# Altium schematic sheet Codec's.SchDoc
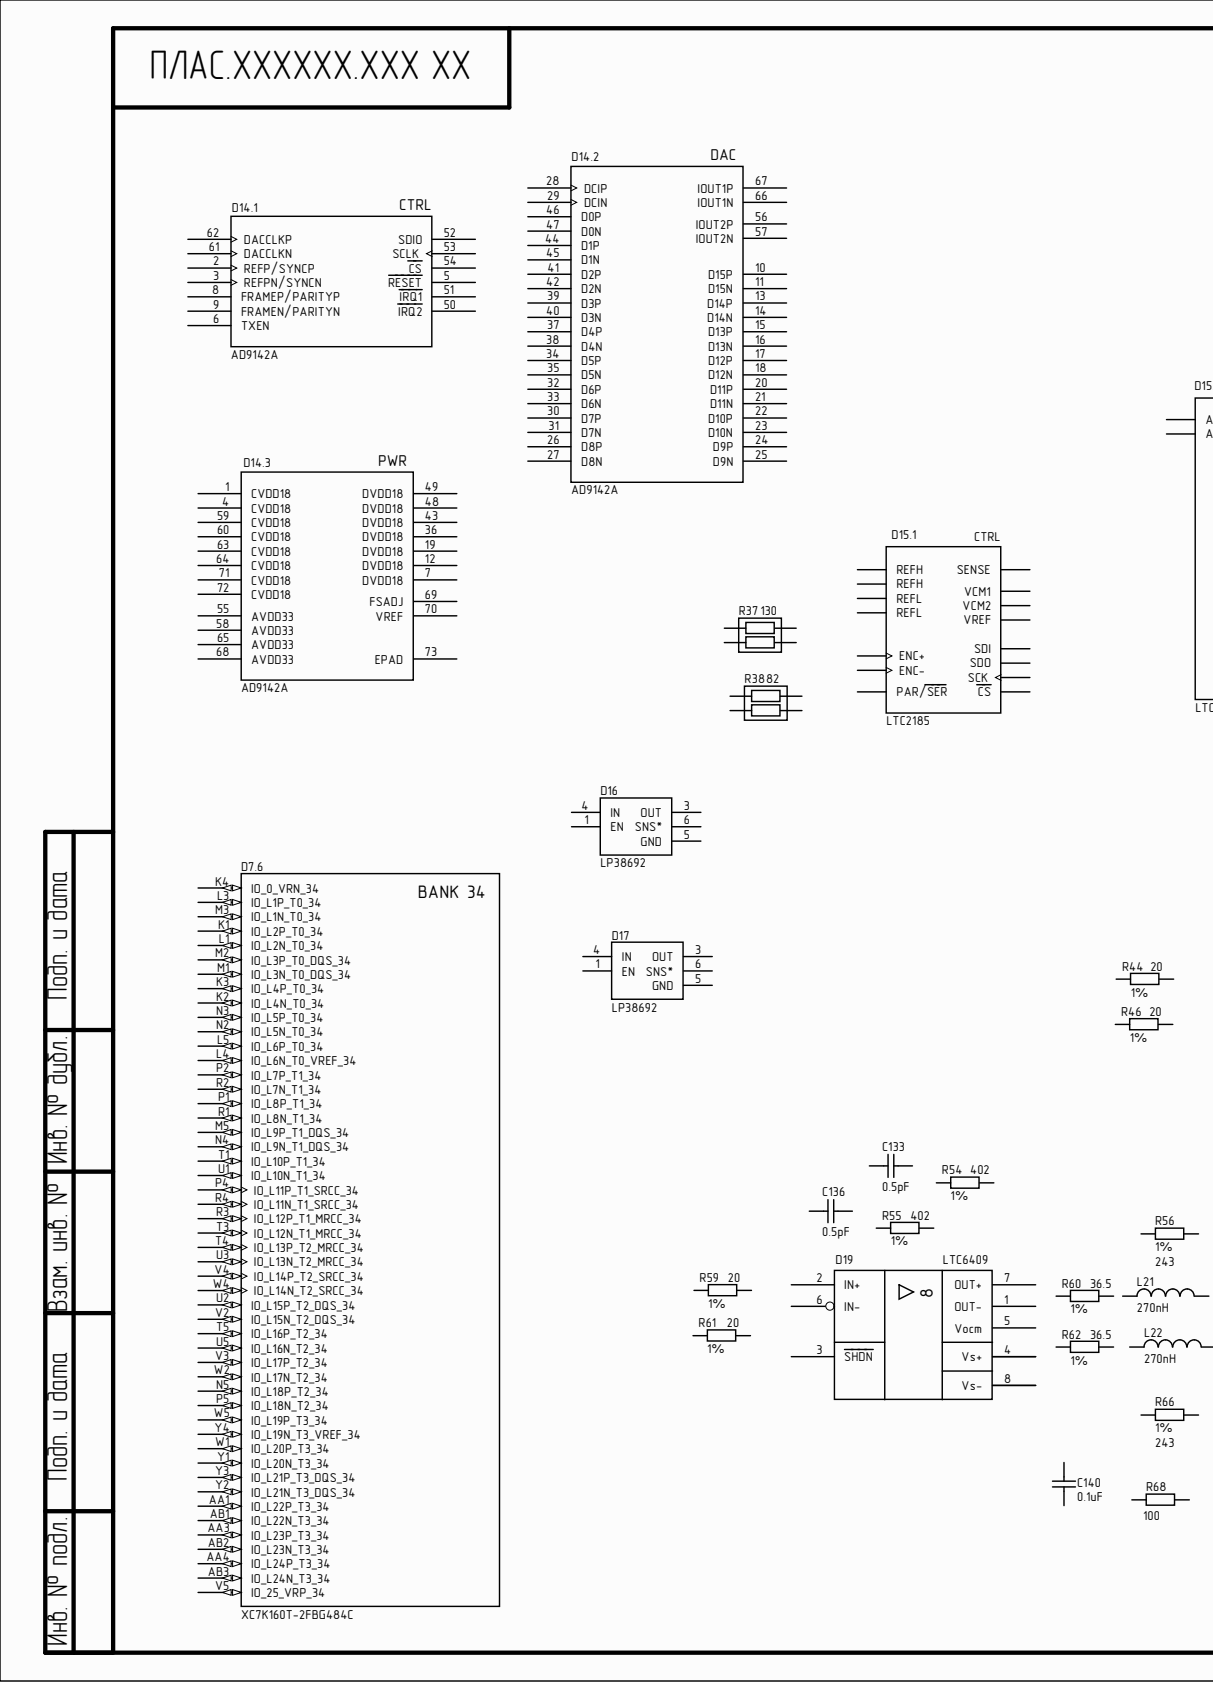
[diagram p0: Codec's.SchDoc, left]
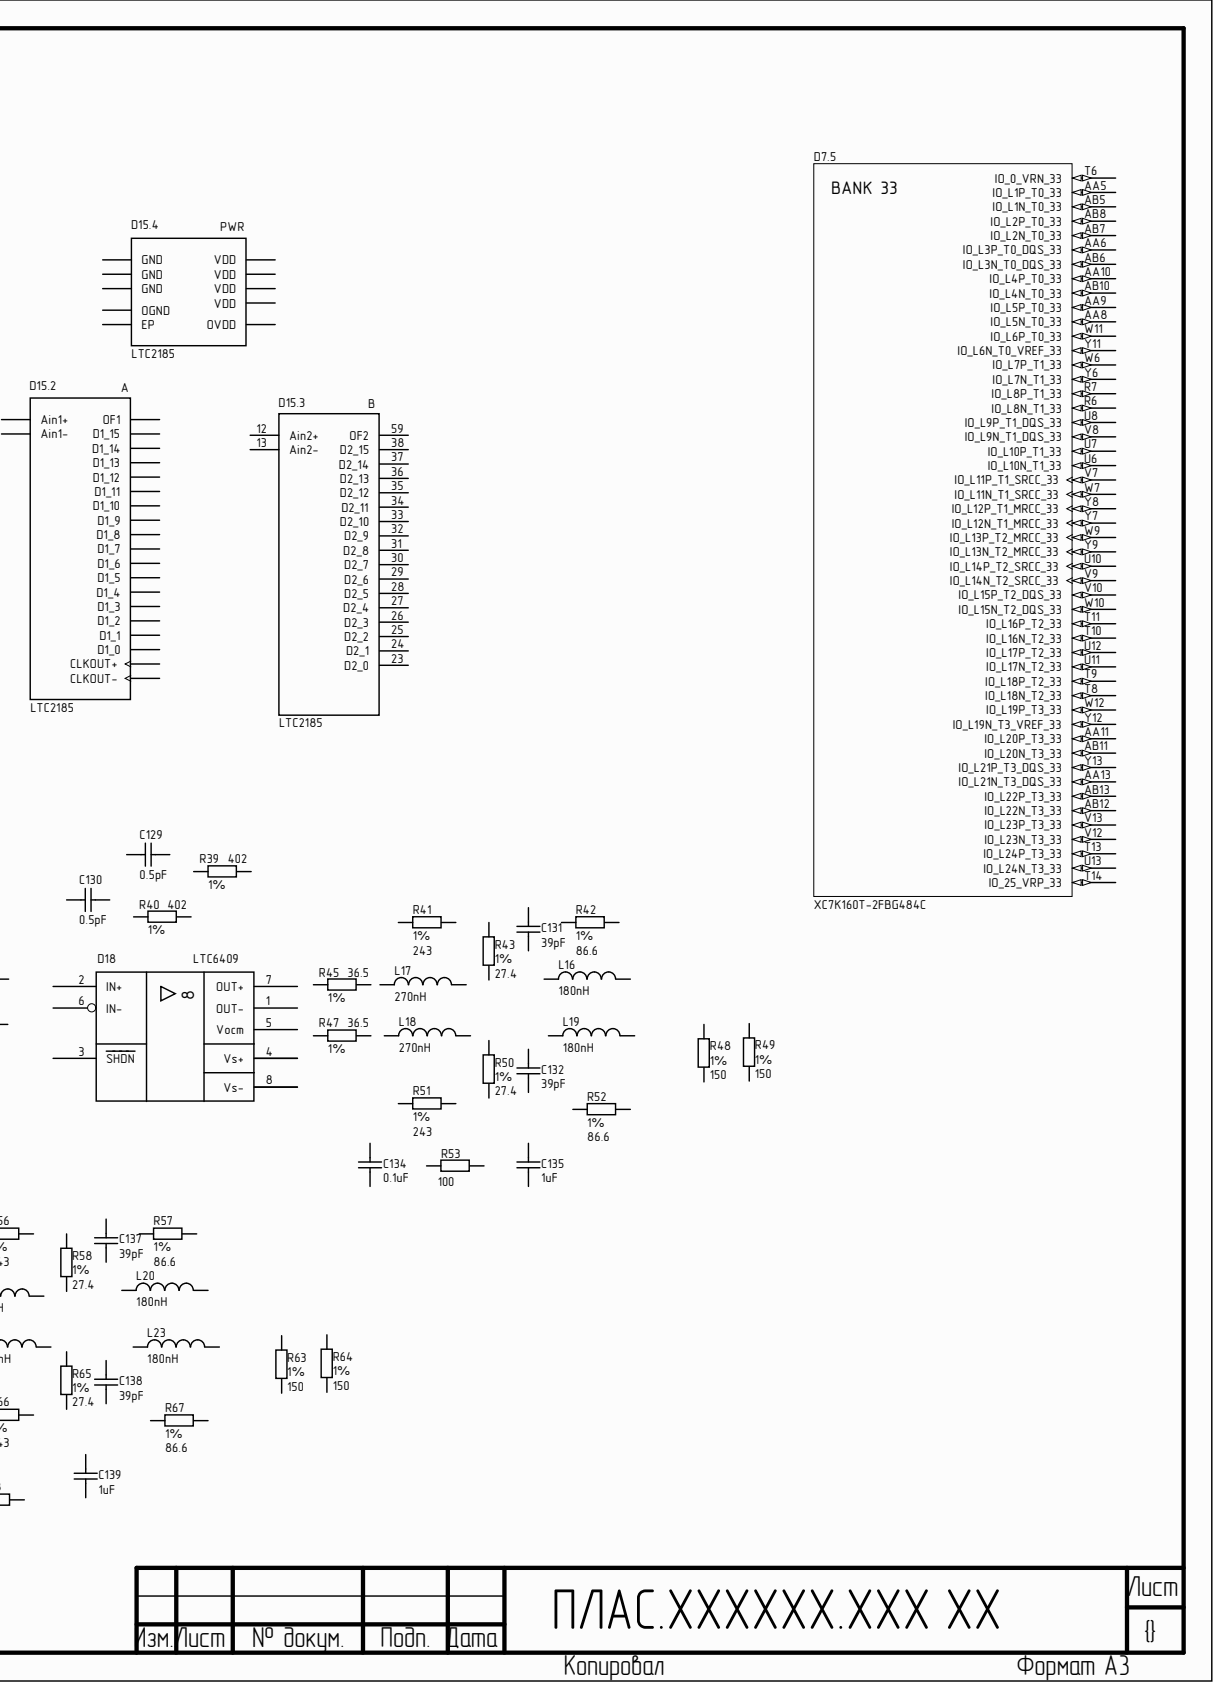
[diagram p1: Codec's.SchDoc, right]

## Parts
C129  value 0.5pF  Tolerance: 0.5pF  [C0402]  (hidden comment: CAP)
C130  value 0.5pF  Tolerance: 0.5pF  [C0402]  (hidden comment: CAP)
C131  value 39pF  [C0402]  (hidden comment: CAP)
C132  value 39pF  Tolerance: 1%  [C0402]  (hidden comment: CAP)
C133  value 0.5pF  Tolerance: 0.5pF  [C0402]  (hidden comment: CAP)
C134  value 0.1uF  [C0402]  (hidden comment: CAP)
C135  value 1uF  [C0402]  (hidden comment: CAP)
C136  value 0.5pF  Tolerance: 0.5pF  [C0402]  (hidden comment: CAP)
C137  value 39pF  Tolerance: 1%  [C0402]  (hidden comment: CAP)
C138  value 39pF  Tolerance: 1%  [C0402]  (hidden comment: CAP)
C139  value 1uF  [C0402]  (hidden comment: CAP)
C140  value 0.1uF  [C0402]  (hidden comment: CAP)
D7  XC7K160T-2FBG484C  [FBG484]  (parts .5,.6)
D14  AD9142A  [LFCSP_VQ-72]  (parts .1,.2,.3)
D15  LTC2185  [QFN-64]  (parts .1,.2,.3,.4)
D16  LP38692  [SOT-223-5]
D17  LP38692  [SOT-223-5]
D18  LTC6409  [QFN-10]
D19  LTC6409  [QFN-10]
L16  value 180nH  [L0603]  (hidden comment: Inductor RF)
L17  value 270nH  [L0603]  (hidden comment: Inductor RF)
L18  value 270nH  [L0603]  (hidden comment: Inductor RF)
L19  value 180nH  [L0603]  (hidden comment: Inductor RF)
L20  value 180nH  [L0603]  (hidden comment: Inductor RF)
L21  value 270nH  [L0603]  (hidden comment: Inductor RF)
L22  value 270nH  [L0603]  (hidden comment: Inductor RF)
L23  value 180nH  [L0603]  (hidden comment: Inductor RF)
R37  value 130  [EX24V]  (hidden comment: RES 2x0402)
R38  value 82  [EX24V]  (hidden comment: RES 2x0402)
R39  value 402  Tolerance: 1%  [R0402]  (hidden comment: RES)
R40  value 402  Tolerance: 1%  [R0402]  (hidden comment: RES)
R41  value 243  Tolerance: 1%  [R0402]  (hidden comment: RES)
R42  value 86.6  Tolerance: 1%  [R0402]  (hidden comment: RES)
R43  value 27.4  Tolerance: 1%  [R0402]  (hidden comment: RES)
R44  value 20  Tolerance: 1%  [R0402]  (hidden comment: RES)
R45  value 36.5  Tolerance: 1%  [R0402]  (hidden comment: RES)
R46  value 20  Tolerance: 1%  [R0402]  (hidden comment: RES)
R47  value 36.5  Tolerance: 1%  [R0402]  (hidden comment: RES)
R48  value 150  Tolerance: 1%  [R0402]  (hidden comment: RES)
R49  value 150  Tolerance: 1%  [R0402]  (hidden comment: RES)
R50  value 27.4  Tolerance: 1%  [R0402]  (hidden comment: RES)
R51  value 243  Tolerance: 1%  [R0402]  (hidden comment: RES)
R52  value 86.6  Tolerance: 1%  [R0402]  (hidden comment: RES)
R53  value 100  [R0402]  (hidden comment: RES)
R54  value 402  Tolerance: 1%  [R0402]  (hidden comment: RES)
R55  value 402  Tolerance: 1%  [R0402]  (hidden comment: RES)
R56  value 243  Tolerance: 1%  [R0402]  (hidden comment: RES)
R57  value 86.6  Tolerance: 1%  [R0402]  (hidden comment: RES)
R58  value 27.4  Tolerance: 1%  [R0402]  (hidden comment: RES)
R59  value 20  Tolerance: 1%  [R0402]  (hidden comment: RES)
R60  value 36.5  Tolerance: 1%  [R0402]  (hidden comment: RES)
R61  value 20  Tolerance: 1%  [R0402]  (hidden comment: RES)
R62  value 36.5  Tolerance: 1%  [R0402]  (hidden comment: RES)
R63  value 150  Tolerance: 1%  [R0402]  (hidden comment: RES)
R64  value 150  Tolerance: 1%  [R0402]  (hidden comment: RES)
R65  value 27.4  Tolerance: 1%  [R0402]  (hidden comment: RES)
R66  value 243  Tolerance: 1%  [R0402]  (hidden comment: RES)
R67  value 86.6  Tolerance: 1%  [R0402]  (hidden comment: RES)
R68  value 100  [R0402]  (hidden comment: RES)

## Nets
NetD18_4: D18.4(Vs+) D18.9
NetD18_8: D18.8(Vs-) D18.11
NetD19_4: D19.4(Vs+) D19.9
NetD19_8: D19.8(Vs-) D19.11

Not connected: C129 1 2; C130 1 2; C131 1 2; C132 1 2; C133 1 2; C134 1 2; C135 1 2; C136 1 2; C137 1 2; C138 1 2; C139 1 2; C140 1 2; D7 AA1(IO_L22P_T3_34) AA3(IO_L23P_T3_34) AA4(IO_L24P_T3_34) AA5(IO_L1P_T0_33) AA6(IO_L3P_T0_DQS_33) AA8(IO_L5N_T0_33) AA9(IO_L5P_T0_33) AA10(IO_L4P_T0_33) AA11(IO_L20P_T3_33) AA13(IO_L21N_T3_DQS_33) AB1(IO_L22N_T3_34) AB2(IO_L23N_T3_34) AB3(IO_L24N_T3_34) AB5(IO_L1N_T0_33) AB6(IO_L3N_T0_DQS_33) AB7(IO_L2N_T0_33) AB8(IO_L2P_T0_33) AB10(IO_L4N_T0_33) AB11(IO_L20N_T3_33) AB12(IO_L22N_T3_33) AB13(IO_L22P_T3_33) K1(IO_L2P_T0_34) K2(IO_L4N_T0_34) K3(IO_L4P_T0_34) K4(IO_0_VRN_34) L1(IO_L2N_T0_34) L3(IO_L1P_T0_34) L4(IO_L6N_T0_VREF_34) L5(IO_L6P_T0_34) M1(IO_L3N_T0_DQS_34) M2(IO_L3P_T0_DQS_34) M3(IO_L1N_T0_34) M5(IO_L9P_T1_DQS_34) N2(IO_L5N_T0_34) N3(IO_L5P_T0_34) N4(IO_L9N_T1_DQS_34) N5(IO_L18P_T2_34) P1(IO_L8P_T1_34) P2(IO_L7P_T1_34) P4(IO_L11P_T1_SRCC_34) P5(IO_L18N_T2_34) R1(IO_L8N_T1_34) R2(IO_L7N_T1_34) R3(IO_L12P_T1_MRCC_34) R4(IO_L11N_T1_SRCC_34) R6(IO_L8N_T1_33) R7(IO_L8P_T1_33) T1(IO_L10P_T1_34) T3(IO_L12N_T1_MRCC_34) T4(IO_L13P_T2_MRCC_34) T5(IO_L16P_T2_34) T6(IO_0_VRN_33) T8(IO_L18N_T2_33) T9(IO_L18P_T2_33) T10(IO_L16N_T2_33) T11(IO_L16P_T2_33) T13(IO_L24P_T3_33) T14(IO_25_VRP_33) U1(IO_L10N_T1_34) U2(IO_L15P_T2_DQS_34) U3(IO_L13N_T2_MRCC_34) U5(IO_L16N_T2_34) U6(IO_L10N_T1_33) U7(IO_L10P_T1_33) U8(IO_L9P_T1_DQS_33) U10(IO_L14P_T2_SRCC_33) U11(IO_L17N_T2_33) U12(IO_L17P_T2_33) U13(IO_L24N_T3_33) V2(IO_L15N_T2_DQS_34) V3(IO_L17P_T2_34) V4(IO_L14P_T2_SRCC_34) V5(IO_25_VRP_34) V7(IO_L11P_T1_SRCC_33) V8(IO_L9N_T1_DQS_33) V9(IO_L14N_T2_SRCC_33) V10(IO_L15P_T2_DQS_33) V12(IO_L23N_T3_33) V13(IO_L23P_T3_33) W1(IO_L20P_T3_34) W2(IO_L17N_T2_34) W4(IO_L14N_T2_SRCC_34) W5(IO_L19P_T3_34) W6(IO_L7P_T1_33) W7(IO_L11N_T1_SRCC_33) W9(IO_L13P_T2_MRCC_33) W10(IO_L15N_T2_DQS_33) W11(IO_L6P_T0_33) W12(IO_L19P_T3_33) Y1(IO_L20N_T3_34) Y2(IO_L21N_T3_DQS_34) Y3(IO_L21P_T3_DQS_34) Y4(IO_L19N_T3_VREF_34) Y6(IO_L7N_T1_33) Y7(IO_L12N_T1_MRCC_33) Y8(IO_L12P_T1_MRCC_33) Y9(IO_L13N_T2_MRCC_33) Y11(IO_L6N_T0_VREF_33) Y12(IO_L19N_T3_VREF_33) Y13(IO_L21P_T3_DQS_33); D14 1(CVDD18) 2(REFP/SYNCP) 3(REFPN/SYNCN) 4(CVDD18) 5(RESET) 6(TXEN) 7(DVDD18) 8(FRAMEP/PARITYP) 9(FRAMEN/PARITYN) 10(D15P) 11(D15N) 12(DVDD18) 13(D14P) 14(D14N) 15(D13P) 16(D13N) 17(D12P) 18(D12N) 19(DVDD18) 20(D11P) 21(D11N) 22(D10P) 23(D10N) 24(D9P) 25(D9N) 26(D8P) 27(D8N) 28(DCIP) 29(DCIN) 30(D7P) 31(D7N) 32(D6P) 33(D6N) 34(D5P) 35(D5N) 36(DVDD18) 37(D4P) 38(D4N) 39(D3P) 40(D3N) 41(D2P) 42(D2N) 43(DVDD18) 44(D1P) 45(D1N) 46(D0P) 47(D0N) 48(DVDD18) 49(DVDD18) 50(IRQ2) 51(IRQ1) 52(SDIO) 53(SCLK) 54(CS) 55(AVDD33) 56(IOUT2P) 57(IOUT2N) 58(AVDD33) 59(CVDD18) 60(CVDD18) 61(DACCLKN) 62(DACCLKP) 63(CVDD18) 64(CVDD18) 65(AVDD33) 66(IOUT1N) 67(IOUT1P) 68(AVDD33) 69(FSADJ) 70(VREF) 71(CVDD18) 72(CVDD18) 73(EPAD); D15 0(EP) 1(VDD) 2(VCM1) 3(GND) 4(Ain1+) 5(Ain1-) 6(GND) 7(REFH) 8(REFL) 9(REFH) 10(REFL) 11(PAR/SER) 12(Ain2+) 13(Ain2-) 14(GND) 15(VCM2) 16(VDD) 17(VDD) 18(ENC+) 19(ENC-) 20(CS) 21(SCK) 22(SDI) 23(D2_0) 24(D2_1) 25(D2_2) 26(D2_3) 27(D2_4) 28(D2_5) 29(D2_6) 30(D2_7) 31(D2_8) 32(D2_9) 33(D2_10) 34(D2_11) 35(D2_12) 36(D2_13) 37(D2_14) 38(D2_15) 39(CLKOUT-) 40(CLKOUT+) 41(OGND) 42(OVDD) 43(D1_0) 44(D1_1) 45(D1_2) 46(D1_3) 47(D1_4) 48(D1_5) 49(D1_6) 50(D1_7) 51(D1_8) 52(D1_9) 53(D1_10) 54(D1_11) 55(D1_12) 56(D1_13) 57(D1_14) 58(D1_15) 59(OF2) 60(OF1) 61(SDO) 62(VREF) 63(SENSE) 64(VDD); D16 1(EN) 3(OUT) 4(IN) 5(GND) 6(SNS*); D17 1(EN) 3(OUT) 4(IN) 5(GND) 6(SNS*); D18 1(OUT-) 2(IN+) 3(SHDN) 5(Vocm) 6(IN-) 7(OUT+); D19 1(OUT-) 2(IN+) 3(SHDN) 5(Vocm) 6(IN-) 7(OUT+); L16 1 2; L17 1 2; L18 1 2; L19 1 2; L20 1 2; L21 1 2; L22 1 2; L23 1 2; R37 1 2 3 4; R38 1 2 3 4; R39 1 2; R40 1 2; R41 1 2; R42 1 2; R43 1 2; R44 1 2; R45 1 2; R46 1 2; R47 1 2; R48 1 2; R49 1 2; R50 1 2; R51 1 2; R52 1 2; R53 1 2; R54 1 2; R55 1 2; R56 1 2; R57 1 2; R58 1 2; R59 1 2; R60 1 2; R61 1 2; R62 1 2; R63 1 2; R64 1 2; R65 1 2; R66 1 2; R67 1 2; R68 1 2

## Library data of the parts
C129 C130 C133 C136 = CAP: SMD Capacitor; Manufacturer: Murata; Material: NP0; P/N: GRM1555C1HR50BA01D; Type: Ceramic Capacitor; Voltage: 50V; Component Kind: Standard; Library Name: Kintex_SDR.SchLib
C131 = CAP: SMD Capacitor; Manufacturer: Murata; Material: NP0; P/N: GRM1555C1H390FA01D; Tolerance: 1%; Type: Ceramic Capacitor; Voltage: 50V; Component Kind: Standard; Library Name: Kintex_SDR.SchLib
C132 C137 C138 = CAP: SMD Capacitor; Manufacturer: Murata; Material: NP0; P/N: GRM1555C1H390FA01D; Type: Ceramic Capacitor; Voltage: 50V; Component Kind: Standard; Library Name: Kintex_SDR.SchLib
C134 C140 = CAP: SMD Capacitor; Manufacturer: Murata; Material: X7R; P/N: GRM155R71H104KE14J; Tolerance: 10%; Type: Ceramic Capacitor; Voltage: 50V; Component Kind: Standard; Library Name: Kintex_SDR.SchLib
C135 C139 = CAP: SMD Capacitor; Manufacturer: Murata; Material: X7R; P/N: GRM155R70J105KA12D; Tolerance: 10%; Type: Ceramic Capacitor; Voltage: 6.3V; Component Kind: Standard; Library Name: Kintex_SDR.SchLib
D7 = XC7K160T-2FBG484C: Xilinx 7-Series FPGA; Speed Grade: -2; Component Kind: Standard; Manufacturer: Xilinx; Name: XC7K160T-2FBG484C; Package: 484 Ball FBG; Series: 7-Series FPGA; Library Name: Kintex_SDR.SchLib
D14 = AD9142A: Dual, 16-Bit, 1600 MSPS, TxDAC+   Digital-to-Analog Converter; Name: AD9142ABCPZ; Power Dissipation: 1.5 W at 1.25 GSPS; Resolution: 16 bit; Sampling Frequency: 575 MHz; Voltage: 3.3V, 1.8V; Component Kind: Standard; Package: 72-lead LFCSP; Temperature Range: −40°C to +85°C; Type: Dual, 16-Bit, 1600 MSPS, TxDAC+   Digital-to-Analog Converter; Library Name: Kintex_SDR.SchLib
D15 = LTC2185: Dual 16-Bit, 125Msps  Low Power 3V ADC; Name: LTC2185CUP#PBF-ND; Power Dissipation: 370mW; Resolution: 16 Bits; Sampling Frequency: 125 MHz; Type: Dual 16-Bit, 105Msps  Low Power 3V ADC; Voltage: Single 1.8V Supply; Component Kind: Standard; Package: 64-Pin (9mm × 9mm) QFN; Temperature Range: 0°C to 70°C; Library Name: Kintex_SDR.SchLib
D16 D17 = LP38692: Linear Regulator; Component Kind: Standard; Library Name: Kintex_SDR.SchLib
D18 D19 = LTC6409: Differential Amplifier/ADC   Driver; Manufacturer: Linear Technology; Name: LTC6409CUDB#TRMPBF; Type: Very high speed, low distortion, differential amplifier.; Voltage: 2.7V to 5.25V; Component Kind: Standard; Package: 10-Lead 3mm ×2mm ×0.75mm QFN; Temperature Range: –40°C to 125°C; Library Name: Kintex_SDR.SchLib
L16 L19 = Inductor RF: Chip SMD Inductor; Manufacturer: Murata; Current: 250mA; Frequency: 1.35GHz; Name: LQW18; P/N: LQW18ANR18G80D; Tolerance: ±2%; Component Kind: Standard; Series: LQW; Temperature Range: -25…85°C; Library Name: Kintex_SDR.SchLib
L17 L18 = Inductor RF: Chip SMD Inductor; Manufacturer: Murata; Current: 250mA; Frequency: 1.25GHz; Name: LQW18; P/N: LQW18ANR27G80D; Tolerance: ±2%; Component Kind: Standard; Series: LQW; Temperature Range: -25…85°C; Library Name: Kintex_SDR.SchLib
L20 L23 = Inductor RF: Chip SMD Inductor; Manufacturer: Murata; Current: 250mA; Frequency: 1.35GHz; Name: LQW18ANR18G80D; Tolerance: ±2%; Component Kind: Standard; Series: LQW; Temperature Range: -25…85°C; Library Name: Kintex_SDR.SchLib
L21 L22 = Inductor RF: Chip SMD Inductor; Manufacturer: Murata; Current: 250mA; Frequency: 1.25GHz; Name: LQW18ANR27G80D; Tolerance: ±2%; Component Kind: Standard; Series: LQW; Temperature Range: -25…85°C; Library Name: Kintex_SDR.SchLib
R37 = RES 2x0402: Chip Resistor Array; Manufacturer: Panasonic; Name: EXB24V; Number of Resistors: 2; P/N: EXB-24V131JX; Tolerance: 5%; Component Kind: Standard; Number of Terminals: 4; Series: EXB; Type: 0402 Array Convex Terminal; Library Name: Kintex_SDR.SchLib
R38 = RES 2x0402: Chip Resistor Array; Manufacturer: Panasonic; Name: EXB24V; Number of Resistors: 2; P/N: EXB-24V820JX; Tolerance: 5%; Component Kind: Standard; Number of Terminals: 4; Series: EXB; Type: 0402 Array Convex Terminal; Library Name: Kintex_SDR.SchLib
R39 R40 R54 R55 = RES: SMD Resistor; Manufacturer: Panasonic Electronic Components; Name: Chip SMD Resistor; P/N: ERJ-2RKF4020X; Power: 0.062 Wt; Component Kind: Standard; Temperature Range: -55…155°C; Library Name: Kintex_SDR.SchLib
R41 R56 = RES: SMD Resistor; Manufacturer: Panasonic Electronic Components; Name: Chip SMD Resistor; P/N: ERJ-2RKF2430X; Power: 0.062 Wt; Value: 243; Component Kind: Standard; Temperature Range: -55…155°C; Library Name: Kintex_SDR.SchLib
R42 R57 = RES: SMD Resistor; Manufacturer: Panasonic Electronic Components; Name: Chip SMD Resistor; P/N: ERJ-2RKF86R6X; Power: 0.062 Wt; Value: 86.6; Component Kind: Standard; Temperature Range: -55…155°C; Library Name: Kintex_SDR.SchLib
R43 R50 R58 R65 = RES: SMD Resistor; Manufacturer: Panasonic Electronic Components; Name: Chip SMD Resistor; P/N: ERJ-2RKF27R4X; Power: 0.062 Wt; Component Kind: Standard; Temperature Range: -55…155°C; Library Name: Kintex_SDR.SchLib
R44 R46 R59 R61 = RES: SMD Resistor; Manufacturer: Panasonic Electronic Components; Name: Chip SMD Resistor; P/N: ERJ-2RKF20R0X; Power: 0.062 Wt; Component Kind: Standard; Temperature Range: -55…155°C; Library Name: Kintex_SDR.SchLib
R45 R47 R60 R62 = RES: SMD Resistor; Manufacturer: Panasonic Electronic Components; Name: Chip SMD Resistor; P/N: ERJ-2RKF36R5X; Power: 0.062 Wt; Component Kind: Standard; Temperature Range: -55…155°C; Library Name: Kintex_SDR.SchLib
R48 R49 R63 R64 = RES: SMD Resistor; Manufacturer: Panasonic Electronic Components; Name: Chip SMD Resistor; P/N: ERJ-2RKF1500X; Power: 0.062 Wt; Component Kind: Standard; Temperature Range: -55…155°C; Library Name: Kintex_SDR.SchLib
R51 R66 = RES: SMD Resistor; Manufacturer: Panasonic Electronic Components; Name: Chip SMD Resistor; P/N: ERJ-2RKF2430X; Power: 0.062 Wt; Component Kind: Standard; Temperature Range: -55…155°C; Library Name: Kintex_SDR.SchLib
R52 R67 = RES: SMD Resistor; Manufacturer: Panasonic Electronic Components; Name: Chip SMD Resistor; P/N: ERJ-2RKF86R6X; Power: 0.062 Wt; Component Kind: Standard; Temperature Range: -55…155°C; Library Name: Kintex_SDR.SchLib
R53 R68 = RES: SMD Resistor; Manufacturer: Panasonic Electronic Components; Name: Chip SMD Resistor; P/N: ERJ-2GEJ101X; Power: 0.062 Wt; Tolerance: 5%; Component Kind: Standard; Temperature Range: -55…155°C; Library Name: Kintex_SDR.SchLib
